# Altium schematic sheet Mega_StepMotor.SchDoc
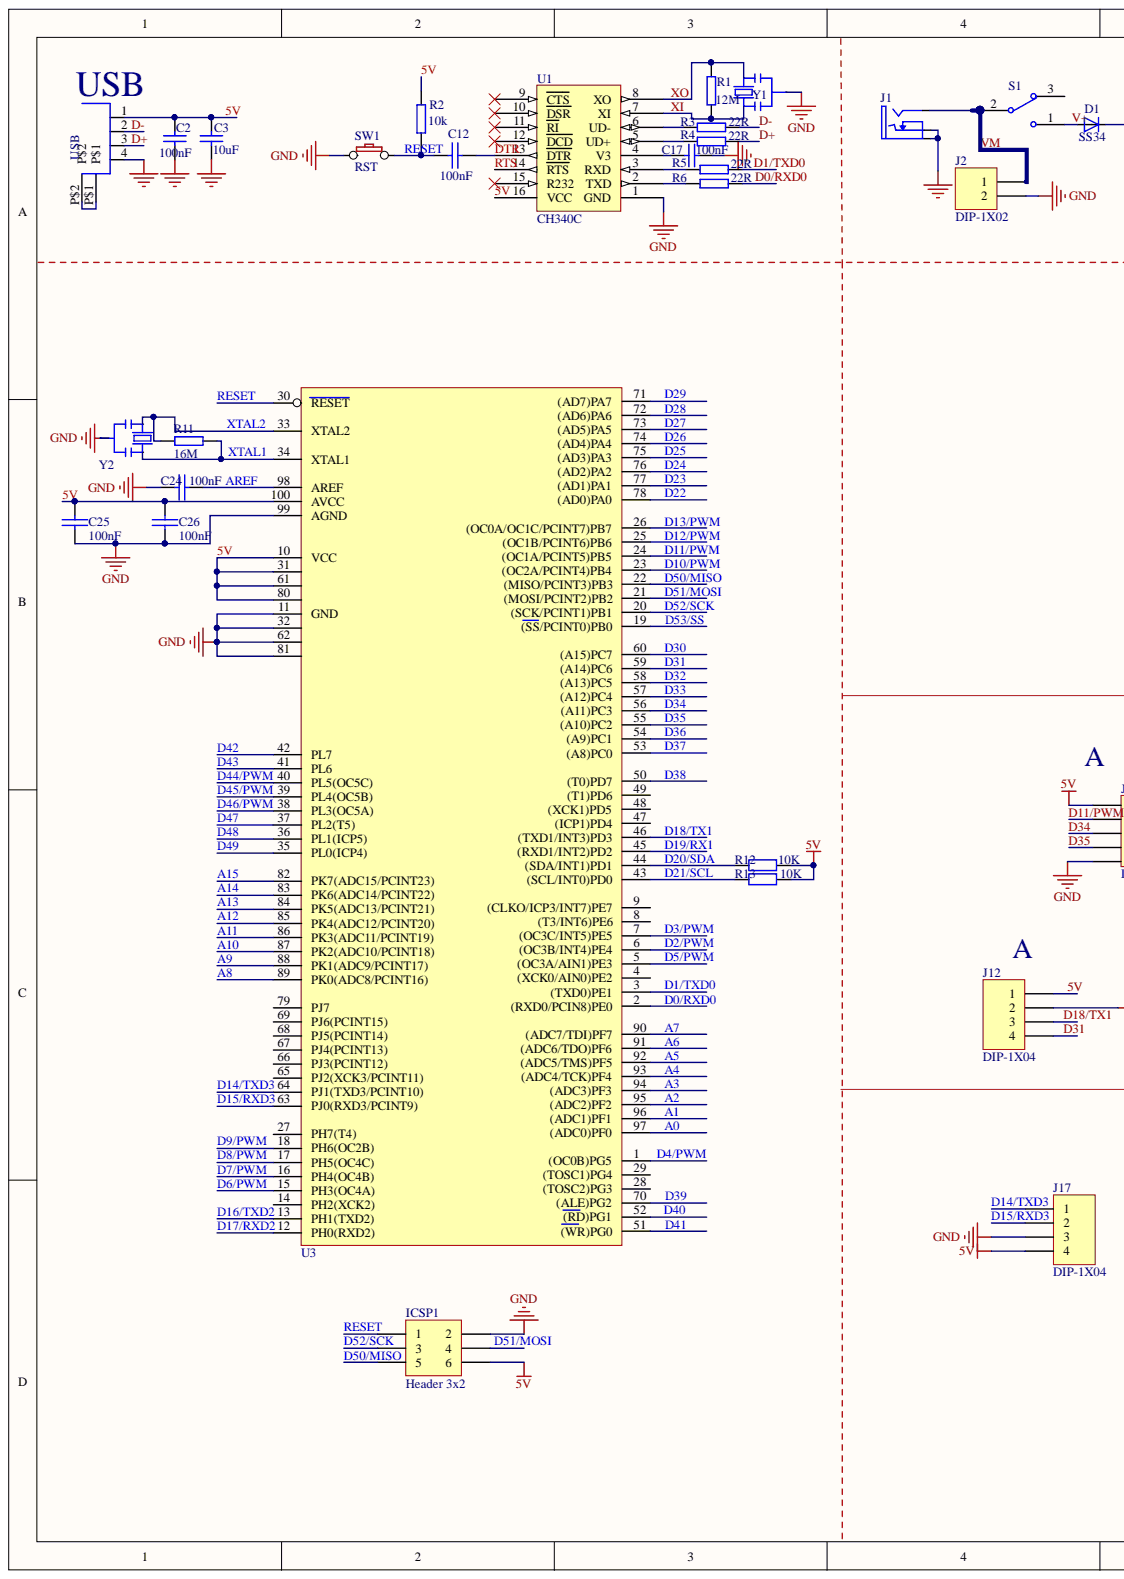
[diagram p0: Mega_StepMotor.SchDoc, left]
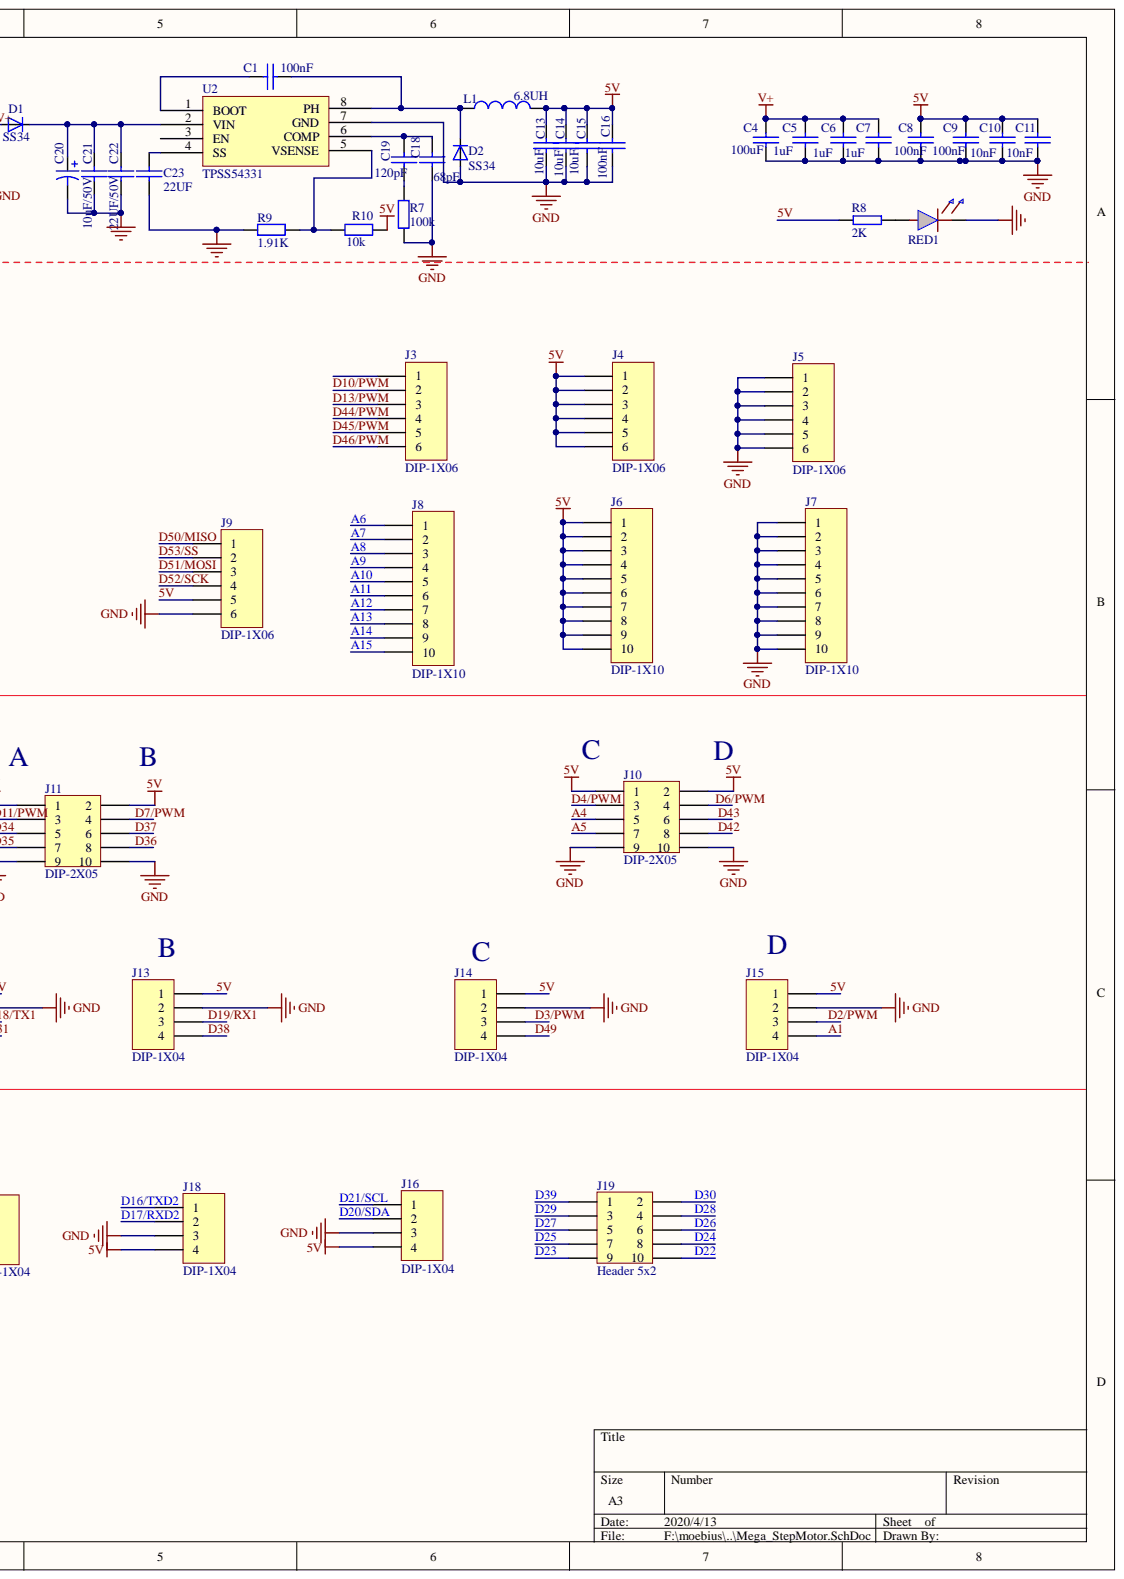
[diagram p1: Mega_StepMotor.SchDoc, right]

## Parts
C1  100nF  [C0603]
C2  100nF  [C0603]
C3  10uF  [C0603]
C4  100uF  [C6.3X6.3]
C5  1uF  [C6.3X6.3]
C6  1uF  [C6.3X6.3]
C7  1uF  [C6.3X6.3]
C8  100nF  [C1206]
C9  100nF  [C0603]
C10  10nF  [C6.3X6.3]
C11  10nF  [C0603]
C12  100nF  [C0603]
C13  10uF  [C0603]
C14  10uF  [C0603]
C15  10uF  [C0603]
C16  100nF  [C0603]
C17  100nF  [C0603]
C18  68pF  [C0603]
C19  120pF  [C0603]
C20  100uF  [C8X8]  (value not drawn)
C21  10uF/50V  [C0603]
C22  22UF/50V  [C0603]
C23  22UF  [C0805]
C24  100nF  [C0603]
C25  100nF  [C0603]
C26  100nF  [C0603]
D1  SS34  [SMA]
D2  SS34  [SMA]
ICSP1  Header 3x2  [HDR2X3]
J1  DC-JACK  [DC-6mm]  (value not drawn)
J2  DIP-1X02  [HX5.08-2L]
J3  DIP-1X06  [DIP-1X06-2.54]
J4  DIP-1X06  [DIP-1X06-2.54]
J5  DIP-1X06  [DIP-1X06-2.54]
J6  DIP-1X10  [HDR1X10]
J7  DIP-1X10  [HDR1X10]
J8  DIP-1X10  [HDR1X10]
J9  DIP-1X06  [DIP-1X06-2.54]
J10  DIP-2X05  [HDR2X5]
J11  DIP-2X05  [HDR2X5]
J12  DIP-1X04  [HDR1X4]
J13  DIP-1X04  [HDR1X4]
J14  DIP-1X04  [HDR1X4]
J15  DIP-1X04  [HDR1X4]
J16  DIP-1X04  [HDR1X4]
J17  DIP-1X04  [HDR1X4]
J18  DIP-1X04  [HDR1X4]
J19  Header 5x2  [HDR2X5]
L1  L  value 6.8UH  [L7X7]
R1  12M  [R0603]
R2  10k  [R0603]
R3  22R  [R0603]
R4  22R  [R0603]
R5  22R  [R0603]
R6  22R  [R0603]
R7  100k  [R0603]
R8  2K  [R0603]
R9  1.91K  [R0603]
R10  10k  [R0603]
R11  16M  [R0603]
R12  10K  [R0603]
R13  10K  [R0603]
RED1  YELLOW  [0603LED R]  (value not drawn)
S1  SW-SPDT  [3PIN-5.08]  (value not drawn)
SW1  RST  [KEY-SMD1]
U1  CH340C  [SOP16]
U2  TPSS54331  [SOP8]
U3  ATMEGA2560-16AU  [TQFP100-Atmega2560]  (value not drawn)
USB-B1  USB-B_TH  [USB-B]  (value not drawn)
Y1  CSTCE16M0V53-R0 16MHZ  [OSC-QS-3PIN]  (value not drawn)
Y2  CSTCE16M0V53-R0 16MHZ  [OSC-QS-3PIN]  (value not drawn)

## Nets
GND: C2.1 C3.1 C4.2 C5.2 C6.2 C7.2 C8.2 C9.2 C10.2 C11.2 C13.1 C14.1 C15.1 C16.1 C17.1 C18.2 C20.2 C21.2 C22.2 C23.2 C24.1 C25.1 C26.1 D2.1 ICSP1.2 J1.2 J1.3 J2.2 J5.1 J5.2 J5.3 J5.4 J5.5 J5.6 J7.1 J7.2 J7.3 J7.4 J7.5 J7.6 J7.7 J7.8 J7.9 J7.10 J9.6 J10.9 J10.10 J11.9 J11.10 J12.2 J13.2 J14.2 J15.2 J16.3 J17.3 J18.3 R7.1 R9.2 RED1.2 SW1.1 U1.1(GND) U2.7(GND) U3.11(GND) U3.32 U3.62 U3.81 U3.99(AGND) USB-B1.4 Y1.2 Y2.2
5V: C2.2 C3.2 C8.1 C9.1 C10.1 C11.1 C13.2 C14.2 C15.2 C16.2 C25.2 C26.2 ICSP1.6 J4.1 J4.2 J4.3 J4.4 J4.5 J4.6 J6.1 J6.2 J6.3 J6.4 J6.5 J6.6 J6.7 J6.8 J6.9 J6.10 J9.5 J10.1 J10.2 J11.1 J11.2 J12.1 J13.1 J14.1 J15.1 J16.4 J17.4 J18.4 L1.2 R2.1 R8.2 R10.2 R12.1 R13.1 U1.16(VCC) U3.10(VCC) U3.31 U3.61 U3.80 U3.100(AVCC) USB-B1.1
A0: U3.97((ADC0)PF0)
A1: J15.4 U3.96((ADC1)PF1)
A2: U3.95((ADC2)PF2)
A3: U3.94((ADC3)PF3)
A4: J10.5 U3.93((ADC4/TCK)PF4)
A5: J10.7 U3.92((ADC5/TMS)PF5)
A6: J8.1 U3.91((ADC6/TDO)PF6)
A7: J8.2 U3.90((ADC7/TDI)PF7)
A8: J8.3 U3.89(PK0(ADC8/PCINT16))
A9: J8.4 U3.88(PK1(ADC9/PCINT17))
A10: J8.5 U3.87(PK2(ADC10/PCINT18))
A11: J8.6 U3.86(PK3(ADC11/PCINT19))
A12: J8.7 U3.85(PK4(ADC12/PCINT20))
A13: J8.8 U3.84(PK5(ADC13/PCINT21))
A14: J8.9 U3.83(PK6(ADC14/PCINT22))
A15: J8.10 U3.82(PK7(ADC15/PCINT23))
AREF: C24.2 U3.98(AREF)
D0/RXD0: R6.2 U3.2((RXD0/PCIN8)PE0)
D1/TXD0: R5.2 U3.3((TXD0)PE1)
D2/PWM: J15.3 U3.6((OC3B/INT4)PE4)
D3/PWM: J14.3 U3.7((OC3C/INT5)PE5)
D4/PWM: J10.3 U3.1((OC0B)PG5)
D5/PWM: U3.5((OC3A/AIN1)PE3)
D6/PWM: J10.4 U3.15(PH3(OC4A))
D7/PWM: J11.4 U3.16(PH4(OC4B))
D8/PWM: U3.17(PH5(OC4C))
D9/PWM: U3.18(PH6(OC2B))
D10/PWM: J3.2 U3.23((OC2A/PCINT4)PB4)
D11/PWM: J11.3 U3.24((OC1A/PCINT5)PB5)
D12/PWM: U3.25((OC1B/PCINT6)PB6)
D13/PWM: J3.3 U3.26((OC0A/OC1C/PCINT7)PB7)
D14/TXD3: J17.1 U3.64(PJ1(TXD3/PCINT10))
D15/RXD3: J17.2 U3.63(PJ0(RXD3/PCINT9))
D16/TXD2: J18.1 U3.13(PH1(TXD2))
D17/RXD2: J18.2 U3.12(PH0(RXD2))
D18/TX1: J12.3 U3.46((TXD1/INT3)PD3)
D19/RX1: J13.3 U3.45((RXD1/INT2)PD2)
D20/SDA: J16.2 R12.2 U3.44((SDA/INT1)PD1)
D21/SCL: J16.1 R13.2 U3.43((SCL/INT0)PD0)
D22: J19.10 U3.78((AD0)PA0)
D23: J19.9 U3.77((AD1)PA1)
D24: J19.8 U3.76((AD2)PA2)
D25: J19.7 U3.75((AD3)PA3)
D26: J19.6 U3.74((AD4)PA4)
D27: J19.5 U3.73((AD5)PA5)
D28: J19.4 U3.72((AD6)PA6)
D29: J19.3 U3.71((AD7)PA7)
D30: J19.2 U3.60((A15)PC7)
D31: J12.4 U3.59((A14)PC6)
D32: U3.58((A13)PC5)
D33: U3.57((A12)PC4)
D34: J11.5 U3.56((A11)PC3)
D35: J11.7 U3.55((A10)PC2)
D36: J11.8 U3.54((A9)PC1)
D37: J11.6 U3.53((A8)PC0)
D38: J13.4 U3.50((T0)PD7)
D39: J19.1 U3.70((ALE)PG2)
D40: U3.52((RD)PG1)
D41: U3.51((WR)PG0)
D42: J10.8 U3.42(PL7)
D43: J10.6 U3.41(PL6)
D44/PWM: J3.4 U3.40(PL5(OC5C))
D45/PWM: J3.5 U3.39(PL4(OC5B))
D46/PWM: J3.6 U3.38(PL3(OC5A))
D47: U3.37(PL2(T5))
D48: U3.36(PL1(ICP5))
D49: J14.4 U3.35(PL0(ICP4))
D50/MISO: ICSP1.5 J9.1 U3.22((MISO/PCINT3)PB3)
D51/MOSI: ICSP1.4 J9.3 U3.21((MOSI/PCINT2)PB2)
D52/SCK: ICSP1.3 J9.4 U3.20((SCK/PCINT1)PB1)
D53/SS: J9.2 U3.19((SS/PCINT0)PB0)
D+: R4.2 USB-B1.3
D-: R3.2 USB-B1.2
DTR: C12.2 U1.13(DTR)
RESET: C12.1 ICSP1.1 R2.2 SW1.2 U3.30(RESET)
RTS: U1.14(RTS)
V+: C4.1 C5.1 C6.1 C7.1 D1.1 S1.1
VM: J1.1 J2.1 S1.2
XI: R1.2 U1.7(XI) Y1.1
XO: R1.1 U1.8(XO) Y1.3
XTAL1: R11.2 U3.34(XTAL1) Y2.3
XTAL2: R11.1 U3.33(XTAL2) Y2.1
NetC1_1: C1.1 U2.1(BOOT)
NetC1_2: C1.2 D2.2 L1.1 U2.8(PH)
NetC17_2: C17.2 U1.4(V3)
NetC18_1: C18.1 C19.1 U2.6(COMP)
NetC19_2: C19.2 R7.2
NetC20_1: C20.1 C21.1 C22.1 D1.2 U2.2(VIN)
NetC23_1: C23.1 U2.4(SS)
NetR3_1: R3.1 U1.6(UD-)
NetR4_1: R4.1 U1.5(UD+)
NetR5_1: R5.1 U1.3(RXD)
NetR6_1: R6.1 U1.2(TXD)
NetR8_1: R8.1 RED1.1
NetR9_1: R9.1 R10.1 U2.5(VSENSE)
NetUSB-B1_P$1: USB-B1.P$1 USB-B1.P$2

Not connected: J3 1; S1 3; U1 9(CTS) 10(DSR) 11(RI) 12(DCD) 15(R232); U2 3(EN); U3 4((XCK0/AIN0)PE2) 8((T3/INT6)PE6) 9((CLKO/ICP3/INT7)PE7) 14(PH2(XCK2)) 27(PH7(T4)) 28((TOSC2)PG3) 29((TOSC1)PG4) 47((ICP1)PD4) 48((XCK1)PD5) 49((T1)PD6) 65(PJ2(XCK3/PCINT11)) 66(PJ3(PCINT12)) 67(PJ4(PCINT13)) 68(PJ5(PCINT14)) 69(PJ6(PCINT15)) 79(PJ7)

## Library data of the parts
C1 C4-C16 C18 C19 C21-C26 = CAP: Capacitor; Component Kind: Standard
C2 C17 = Cap: Capacitor; Component Kind: Standard; LatestRevisionDate: 17-Jul-2002; LatestRevisionNote: Re-released for DXP Platform.; PackageDescription: Radial Cap, Thru-Hole; 2 Leads; 0.3 in Pin Spacing; PackageReference: RAD-0.3; Signal Integrity: Cap; Simulation: CAP; Library Name: accessory.SchLib; Publisher: Altium Limited
C3 = Cap: Capacitor; Value: 0.1UF; Component Kind: Standard; LatestRevisionDate: 17-Jul-2002; LatestRevisionNote: Re-released for DXP Platform.; PackageDescription: Radial Cap, Thru-Hole; 2 Leads; 0.3 in Pin Spacing; PackageReference: RAD-0.3; Published: 8-Jun-2000; Signal Integrity: Cap; Simulation: CAP; Library Name: accessory.SchLib; Publisher: Altium Limited
C20 = Cap Pol1: Polarized Capacitor (Radial); Value: 100F; Component Kind: Standard; LatestRevisionDate: 17-Jul-2002; LatestRevisionNote: Re-released for DXP Platform.; Library Name: Miscellaneous Devices.IntLib; PackageDescription: Polarized Capacitor (Radial); 2 Leads; PackageReference: RB7.6-15; Published: 8-Jun-2000; Signal Integrity: Cap Pol; Simulation: CAP; Publisher: Altium Limited
D1 D2 = DIODE: Component Kind: Standard; Library Name: accessory.SchLib
ICSP1 = DIP-2X03: Header, 3-Pin, Dual row; Component Kind: Standard; LatestRevisionDate: 17-Jul-2002; LatestRevisionNote: Re-released for DXP Platform.; Library Name: connector.SchLib; Signal Integrity: Connector; Publisher: Altium Limited
J2 = DIP-1X02: Header, 2-Pin; Component Kind: Standard; LatestRevisionDate: 17-Jul-2002; LatestRevisionNote: Re-released for DXP Platform.; Library Name: connector.SchLib; Publisher: Altium Limited
J3-J5 J9 = DIP-1X06: Header, 6-Pin; Component Kind: Standard; LatestRevisionDate: 17-Jul-2002; LatestRevisionNote: Re-released for DXP Platform.; Library Name: connector.SchLib; Signal Integrity: Connector; Publisher: Altium Limited
J6-J8 = DIP-1X10: Header, 10-Pin; Component Kind: Standard; LatestRevisionDate: 17-Jul-2002; LatestRevisionNote: Re-released for DXP Platform.; Library Name: connector.SchLib; Signal Integrity: Connector; Publisher: Altium Limited
J10 J11 J19 = DIP-2X05: Header, 10-Pin, Dual row; Component Kind: Standard; LatestRevisionDate: 17-Jul-2002; LatestRevisionNote: Re-released for DXP Platform.; Library Name: connector.SchLib; Signal Integrity: Connector; Publisher: Altium Limited
J12-J18 = DIP-1X04: Header, 4-Pin; Component Kind: Standard; LatestRevisionDate: 17-Jul-2002; LatestRevisionNote: Re-released for DXP Platform.; Library Name: connector.SchLib; Signal Integrity: Connector; Publisher: Altium Limited
L1 = L: Inductor; Code_IEC: 1005; Code_JEITA: 0402; Component Kind: Standard; LatestRevisionDate: 29-May-2009; LatestRevisionNote: IPC-7351 Footprint Added.; PackageDescription: Chip Inductor; PackageReference: 0402-A; Published: 8-Jun-2000; Signal Integrity: Inductor; Simulation: INDUCTOR; Library Name: accessory.SchLib; Publisher: Altium Limited
R1-R6 R8 R11 = SMT_R_0402: Surface mount resistor 0402; Component Kind: Standard; Library Name: Vowstar_Basic_Device.IntLib
R7 = Res2: Resistor; Component Kind: Standard; LatestRevisionDate: 17-Jul-2002; LatestRevisionNote: Re-released for DXP Platform.; Library Name: Miscellaneous Devices.IntLib; PackageDescription: Axial Device, Thru-Hole; 2 Leads; 0.4 in Pin Spacing; PackageReference: AXIAL-0.4; Published: 8-Jun-2000; Signal Integrity: Res; Simulation: RESISTOR; Value: 100K; Publisher: Altium Limited
R9 R10 R12 R13 = Res2: Resistor; Value: 100K; Component Kind: Standard; LatestRevisionDate: 17-Jul-2002; LatestRevisionNote: Re-released for DXP Platform.; Library Name: Miscellaneous Devices.IntLib; PackageDescription: Axial Device, Thru-Hole; 2 Leads; 0.4 in Pin Spacing; PackageReference: AXIAL-0.4; Published: 8-Jun-2000; Signal Integrity: Res; Simulation: RESISTOR; Publisher: Altium Limited
RED1 = LED: Component Kind: Standard; Library Name: accessory.SchLib
S1 = SW-SPDT: SPDT Subminiature Toggle Switch, Right Angle Mounting, Vertical Actuation; Component Kind: Standard; ComponentLink1Description: Manufacturer Link; ComponentLink1URL: http://www.apem.com; DatasheetVersion: 05-Dec-2000; LatestRevisionDate: 17-Dec-2003; LatestRevisionNote: Schematic revised, PCB Footprint replaced.; Library Name: Miscellaneous Devices.IntLib; PackageDescription: Switch; 4 Leads; PackageReference: TL36WW15050; Published: 24-Mar-1999; Publisher: Altium Limited
SW1 = BT: Component Kind: Standard; Library Name: accessory.SchLib
U1 = CH340G: CH340G USB to serial (UART) bridge; Component Kind: Standard; Library Name: Vowstar_IC_General.IntLib
U3 = ATMEGA2560: Component Kind: Standard; Library Name: chip1.SchLib
USB-B1 = PN61729: Component Kind: Standard; Library Name: SmartPrj
Y1 Y2 = OSC-QS: Component Kind: Standard; Library Name: accessory.SchLib
J1 = DC-JACK: Component Kind: Standard; Library Name: connector.SchLib
U2 = TPSS54331: Component Kind: Standard; Library Name: chip1.SchLib
